# Altium schematic sheet Page2_Power.SchDoc
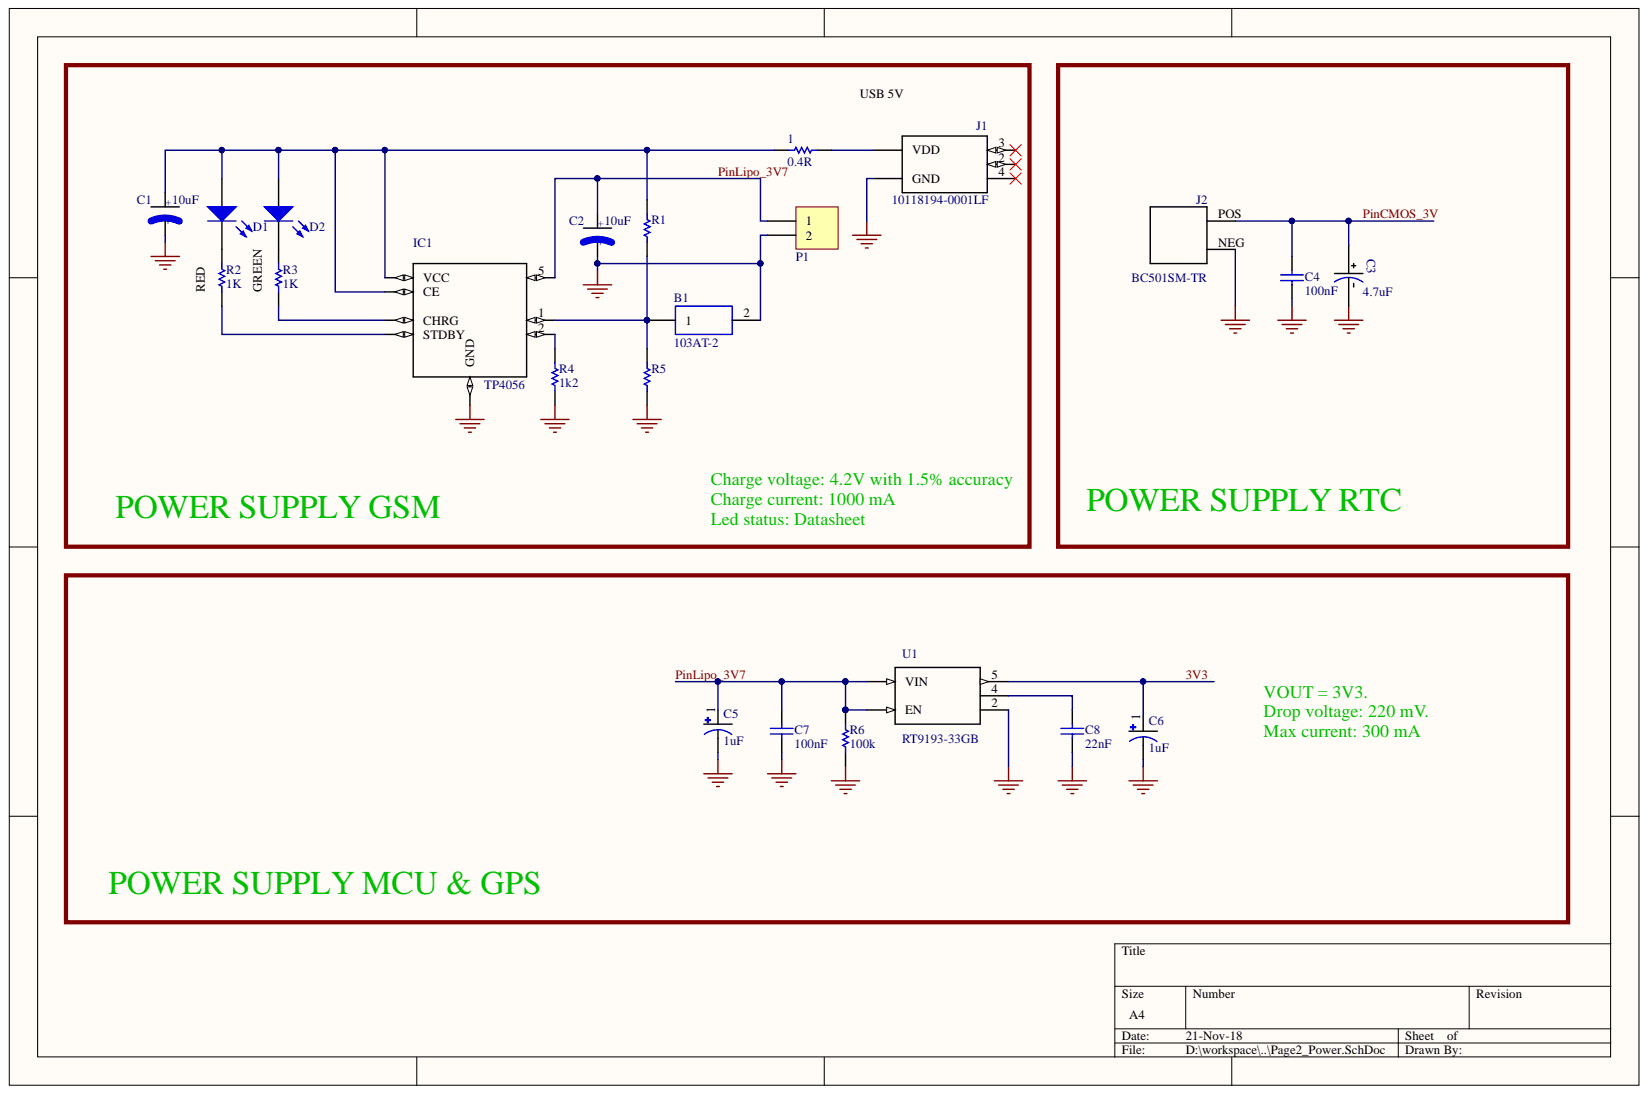
[diagram p0: Page2_Power.SchDoc]

## Parts
1  value 0.4R  [RES-SM-0603]  (hidden comment: RESISTOR SMD)
B1  103AT-2  [103AT2]
C1  10uF  [AVX-A_TANTALUM-SMD-10UF-10V-10%(AVX-A)(Primary)]
C2  10uF  [AVX-A_TANTALUM-SMD-10UF-10V-10%(AVX-A)(Primary)]
C3  4.7uF  [CAPMP3216X166_TAJA475K016RNJ(Primary)]
C4  value 100nF  [CAP-SM-0603]  (hidden comment: Cap Non-Pol SMD)
C5  1uF  [CAPMP3216X180N]
C6  1uF  [CAPMP3216X180N]
C7  value 100nF  [CAP-SM-0603]  (hidden comment: Cap Non-Pol SMD)
C8  value 22nF  [CAP-SM-0603]  (hidden comment: Cap Non-Pol SMD)
D1  LED SMD  [LED_0603_Blue]  (value not drawn)
D2  LED SMD  [LED_0603_Blue]  (value not drawn)
IC1  TP4056  [SOP-8]
J1  10118194-0001LF  [FRAMATOME_10118194-0001LF]
J2  BC501SM-TR  [MPD_BC501SM-TR_BC501SM-TR(Primary)]
P1  Header 2  [JP2]  (value not drawn)
R1  RESISTOR SMD  [RES-SM-0603]  (value not drawn)
R2  value 1K  [RES-SM-0603]  (hidden comment: RESISTOR SMD)
R3  value 1K  [RES-SM-0603]  (hidden comment: RESISTOR SMD)
R4  value 1k2  [RES-SM-0603]  (hidden comment: RESISTOR SMD)
R5  RESISTOR SMD  [RES-SM-0603]  (value not drawn)
R6  value 100k  [RES-SM-0603]  (hidden comment: RESISTOR SMD)
U1  RT9193-33GB  [SOT94P279X129-5N]

## Nets
GND: B1.2 C1.NEG C2.NEG C3.NEG C4.1 C5.2 C6.2 C7.2 C8.1 IC1.3(GND) J1.5(GND) J2.NEG P1.2 R4.1 R5.1 R6.1 U1.2
3V3: C6.1 U1.5
PinCMOS_3V: C3.POS C4.2 J2.POS
PinLipo_3V7: C2.POS C5.1 C7.1 IC1.5 P1.1 R6.2 U1.1(VIN) U1.3(EN)
Net1_1: 1.1 J1.1(VDD)
Net1_2: 1.2 C1.POS D1.1 D2.1 IC1.4(VCC) IC1.8(CE) R1.2
NetB1_1: B1.1 IC1.1 R1.1 R5.2
NetC8_2: C8.2 U1.4
NetD1_2: D1.2 R2.2
NetD2_2: D2.2 R3.2
NetIC1_2: IC1.2 R4.2
NetIC1_6: IC1.6(STDBY) R2.1
NetIC1_7: IC1.7(CHRG) R3.1

Not connected: J1 2 3 4

## Library data of the parts
1 R1-R6 = RESISTOR SMD: Resistor; Component Kind: Standard; Library Name: PIF_Library.IntLib
B1 = 103AT-2: NTC Thermistors 10kohm 1%; Manufacturer_Name: Semitec; Geometry.Height: 8mm; Arrow Part Number: 103AT-2; Component Kind: Standard; Datasheet Link: http://www.semitec-usa.com/downloads/atthermistor.pdf; Library Name: 103AT-2.SchLib; Manufacturer_Part_Number: 103AT-2
C1 C2 = TANTALUM-SMD-10UF-10V-10%(AVX-A): Cap Tant Solid 10uF 10V A CASE 10% _3.2 X 1.6 X 1.6mm_ SMD 3216-18 3 Ohm 125Â°C T/R; MF: AVX Interconnect / Elco; MP: TAJA106K010RNJ; Availability: Unavailable; Component Kind: Standard; Library Name: TANTALUM-SMD-10UF-10V-10__AVX-A_.SchLib; Package: 1206 AVX Interconnect / Elco; Price: None; SnapEDA_Link: https://www.snapeda.com/parts/TAJA106K010RNJ/AVX%20Interconnect%20/%20Elco/view-part/?ref=snap
C3 = TAJA475K016RNJ: Cap Tant Solid 4.7uF 16V A CASE 10% _3.2 X 1.6 X 1.6mm_ SMD 3216-18 4 Ohm 125Â°C T/R; MF: AVX; Availability: Unavailable; Component Kind: Standard; Library Name: TAJA475K016RNJ.SchLib; MP: TAJA475K016RNJ; Package: 1206 AVX; Price: None; SnapEDA_Link: https://www.snapeda.com/parts/TAJA475K016RNJ/AVX%20/%20Kyocera/view-part/?ref=snap
C4 C7 C8 = Cap Non-Pol SMD: Capacitor; Component Kind: Standard; Library Name: PIF_Library.IntLib
C5 C6 = TAJA105K016RNJ: Cap Tant Solid 1uF 16V A CASE 10% _3.2 X 1.6 X 1.6mm_ SMD 3216-18 11 Ohm 125C T/R; MF: AVX; Availability: Unavailable; Component Kind: Standard; Library Name: TAJA105K016RNJ.SchLib; MP: TAJA105K016RNJ; Package: 1206 AVX; Price: None; SnapEDA_Link: https://www.snapeda.com/parts/TAJA105K016RNJ/AVX%20/%20Kyocera/view-part/?ref=snap
D1 D2 = LED SMD: LED; Component Kind: Standard; Library Name: PIF_Library.IntLib
IC1 = TP4056: MF: NanJing Top Power ASIC Corp.; Availability: Unavailable; Component Kind: Standard; Library Name: TP4056.SchLib; MP: TP4056; Package: Package Analog Devices; Price: None; SnapEDA_Link: https://www.snapeda.com/parts/TP4056%20/NanJing%20Top%20Power%20ASIC%20Corp./view-part/?ref=snap
J1 = 10118194-0001LF: Micro Usb, 2.0 Type b, Rcpt, Smt; MF: Amphenol FCI; Availability: Unavailable; Component Kind: Standard; Library Name: USB Type B.SchLib; MP: 10118194-0001LF; Package: None; Price: None; SnapEDA_Link: https://www.snapeda.com/parts/10118194-0001LF/Amphenol%20FCI/view-part/?ref=snap
J2 = BC501SM-TR: Holder Coin Cell For Cr1220 Smd; MF: MPD _Memory; Availability: Unavailable; Component Kind: Standard; Library Name: BC501SM-TR.SchLib; MP: BC501SM-TR; Package: DFN-8 MPD; Price: None; SnapEDA_Link: https://www.snapeda.com/parts/BC501SM-TR/MPD%20%28Memory%20Protection%20Devices%29/view-part/?ref=snap
P1 = Header 2: Header, 2-Pin; Component Kind: Standard; LatestRevisionDate: 17-Jul-2002; LatestRevisionNote: Re-released for DXP Platform.; Library Name: PIF_Library.IntLib; Publisher: Altium Limited
U1 = RT9193-33GB: RT9193 Series 300 mA 3.3 V Ultra-Low Noise Ultra-Fast CMOS LDO Regulator-SC-70-5; MF: Richtek USA; Availability: Unavailable; Component Kind: Standard; Library Name: RT9193-33GB.SchLib; MP: RT9193-33GB; Package: SOT-23-5 Richtek; Price: None; SnapEDA_Link: https://www.snapeda.com/parts/RT9193-33GB/Richtek%20USA%20Inc./view-part/?ref=snap
